# Altium schematic sheet ESP32 Relay_main.SchDoc("ESP32 Relay_main")
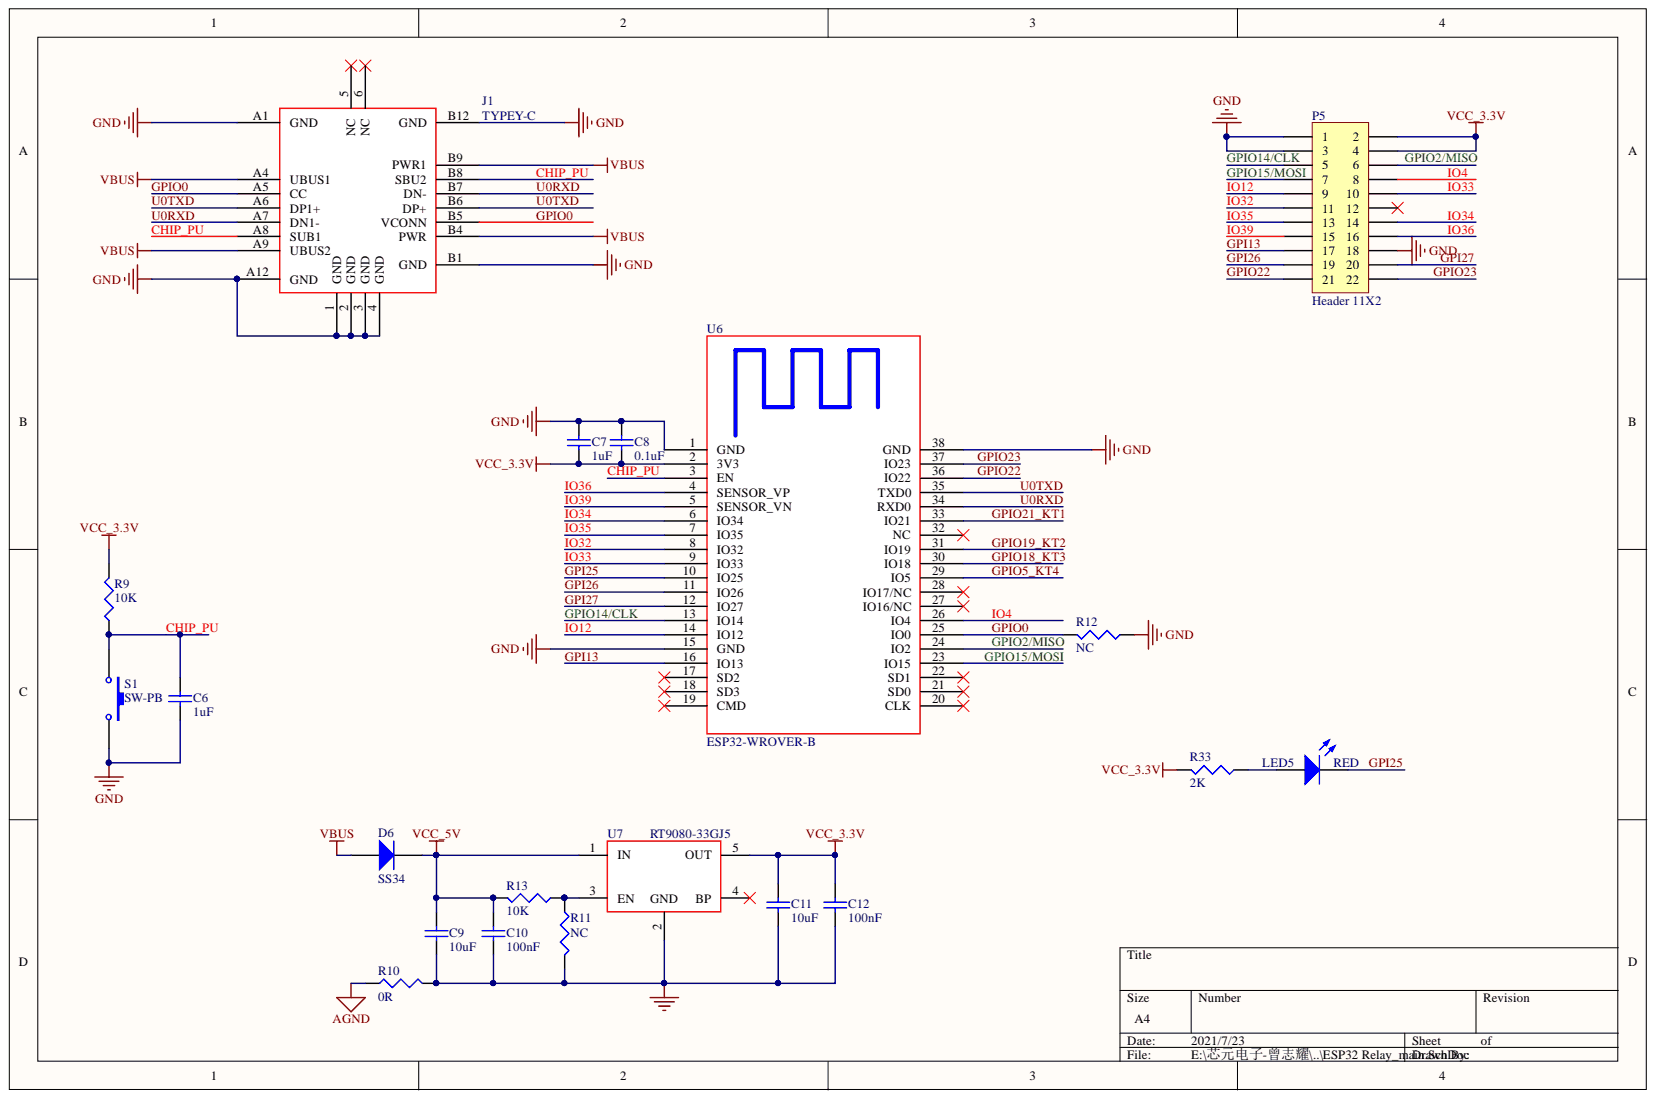
[diagram p0: ESP32 Relay_main.SchDoc]

## Parts
C6  1uF  [C0402]
C7  1uF  [C0402]
C8  0.1uF  [C0402]
C9  10uF  [C0805]
C10  100nF  [C0402]
C11  10uF  [C0805]
C12  100nF  [C0402]
D6  SS34  [SMA]
J1  TYPEY-C  [TYPE-C3.0]
LED5  RED  [LED-1204]
P5  Header 11X2  [HDR2X11]
R9  10K  [0402a]
R10  0R  [R 1206_L]
R11  NC  [0402A]
R12  NC  [0402a]
R13  10K  [0402A]
R33  2K  [0402a]
S1  SW-PB  [SW-SMD_4P-L6.0-W6.0-P4.50-LS9.0]
U6  ESP32-WROVER-B  [ESP32-WROVER-B]
U7  RT9080-33GJ5  [SOT23-5]

## Nets
AGND: R10.1
GND: C6.1 C7.2 C8.2 C9.1 C10.1 C11.1 C12.1 J1.1(GND) J1.2(GND) J1.3(GND) J1.4(GND) J1.A1(GND) J1.A12(GND) J1.B1(GND) J1.B12(GND) P5.1 P5.3 P5.18 R10.2 R11.2 R12.1 S1.3 U6.1(GND) U6.15(GND) U6.38(GND) U7.2
VBUS: D6.1 J1.A4(UBUS1) J1.A9(UBUS2) J1.B4(PWR) J1.B9(PWR1)
VCC_3.3V: C7.1 C8.1 C11.2 C12.2 P5.2 P5.4 R9.2 R33.2 U6.2(3V3) U7.5(OUT)
VCC_5V: C9.2 C10.2 D6.2 R13.2 U7.1(IN)
CHIP_PU: C6.2 J1.A8(SUB1) J1.B8(SBU2) R9.1 S1.2 U6.3(EN)
GPI13: P5.17 U6.16(IO13)
GPI25: LED5.2 U6.10(IO25)
GPI26: P5.19 U6.11(IO26)
GPI27: P5.20 U6.12(IO27)
GPIO0: J1.A5(CC) J1.B5(VCONN) R12.2 U6.25(IO0)
GPIO2/MISO: P5.6 U6.24(IO2)
GPIO5_KT4: U6.29(IO5)
GPIO14/CLK: P5.5 U6.13(IO14)
GPIO15/MOSI: P5.7 U6.23(IO15)
GPIO18_KT3: U6.30(IO18)
GPIO19_KT2: U6.31(IO19)
GPIO21_KT1: U6.33(IO21)
GPIO22: P5.21 U6.36(IO22)
GPIO23: P5.22 U6.37(IO23)
IO4: P5.8 U6.26(IO4)
IO12: P5.9 U6.14(IO12)
IO32: P5.11 U6.8(IO32)
IO33: P5.10 U6.9(IO33)
IO34: P5.14 U6.6(IO34)
IO35: P5.13 U6.7(IO35)
IO36: P5.16 U6.4(SENSOR_VP)
IO39: P5.15 U6.5(SENSOR_VN)
U0RXD: J1.A7(DN1-) J1.B7(DN-) U6.34(RXD0)
U0TXD: J1.A6(DP1+) J1.B6(DP+) U6.35(TXD0)
NetLED5_1: LED5.1 R33.1
NetR11_1: R11.1 R13.1 U7.3(EN)

Not connected: J1 5(NC) 6(NC); P5 12; U6 17(SD2) 18(SD3) 19(CMD) 20(CLK) 21(SD0) 22(SD1) 27(IO16/NC) 28(IO17/NC) 32(NC); U7 4(BP)

## Library data of the parts
C6-C12 = Cap: Capacitor; Value: 100pF; Simulation: CAP; Signal Integrity: Cap; Published: 8-Jun-2000; PackageReference: RAD-0.3; PackageDescription: Radial Cap, Thru-Hole; 2 Leads; 0.3 in Pin Spacing; Library Name: Miscellaneous Devices.IntLib; LatestRevisionNote: Re-released for DXP Platform.; LatestRevisionDate: 17-Jul-2002; Component Kind: Standard; Publisher: Altium Limited
D6 = Diode: Default Diode; Simulation: DIODE; Signal Integrity: Diode; Published: 8-Jun-2000; PackageVersion: Sep-1996; PackageReference: SMC; PackageDescription: DO-214-AB/SMC; 2 C-Bend Leads; Body 7.9 x 5.9 mm, inc. leads (LxW); Library Name: Miscellaneous Devices.IntLib; LatestRevisionNote: Re-released for DXP Platform.; LatestRevisionDate: 17-Jul-2002; Component Kind: Standard; Code_JEDEC: DO-214-AB; Publisher: Altium Limited
J1 = TYPE-C: Library Name: 原理图库.SchLib; Component Kind: Standard
LED5 = LED2: Typical RED, GREEN, YELLOW, AMBER GaAs LED; Simulation: LED2; Published: 8-Jun-2000; PackageVersion: Feb-2002; PackageReference: 3.2X1.6X1.1; PackageDescription: SM LED; 2 Flat Leads; Library Name: Miscellaneous Devices.IntLib; LatestRevisionNote: Re-released for DXP Platform.; LatestRevisionDate: 17-Jul-2002; Component Kind: Standard; Publisher: Altium Limited
P5 = Header 11X2: Header, 11-Pin, Dual row; Signal Integrity: Connector; Library Name: Miscellaneous Connectors.IntLib; LatestRevisionNote: Re-released for DXP Platform.; LatestRevisionDate: 17-Jul-2002; Component Kind: Standard; Publisher: Altium Limited
R9 R12 R33 = RES-0402: Library Name: 原理图库.SchLib; Component Kind: Standard
R10 R11 R13 = Res3: Resistor; Simulation: RESISTOR; Signal Integrity: Res; Published: 8-Jun-2000; PackageReference: J1-0603; PackageDescription: Chip Resistor; Library Name: Miscellaneous Devices.IntLib; LatestRevisionNote: IPC-7351 Footprint Added.; LatestRevisionDate: 29-May-2009; Component Kind: Standard; Publisher: Altium Limited
  R10: Value: 0R
  R11: Value: NC
  R13: Value: 10K
S1 = SW-PB: Switch; Published: 24-Mar-1999; PackageReference: SPST-2; PackageDescription: Switch; 2 Leads; Library Name: Miscellaneous Devices.IntLib; LatestRevisionNote: Re-released for DXP Platform.; LatestRevisionDate: 17-Jul-2002; Component Kind: Standard; Publisher: Altium Limited
U6 = ESP32-WROVER-B: Library Name: 原理图库.SchLib; Component Kind: Standard
U7 = RT9080-33GJ5: Library Name: 原理图库.SchLib; Component Kind: Standard
